# Altium schematic sheet PIN(15~16).SchDoc(PIN(15~16))
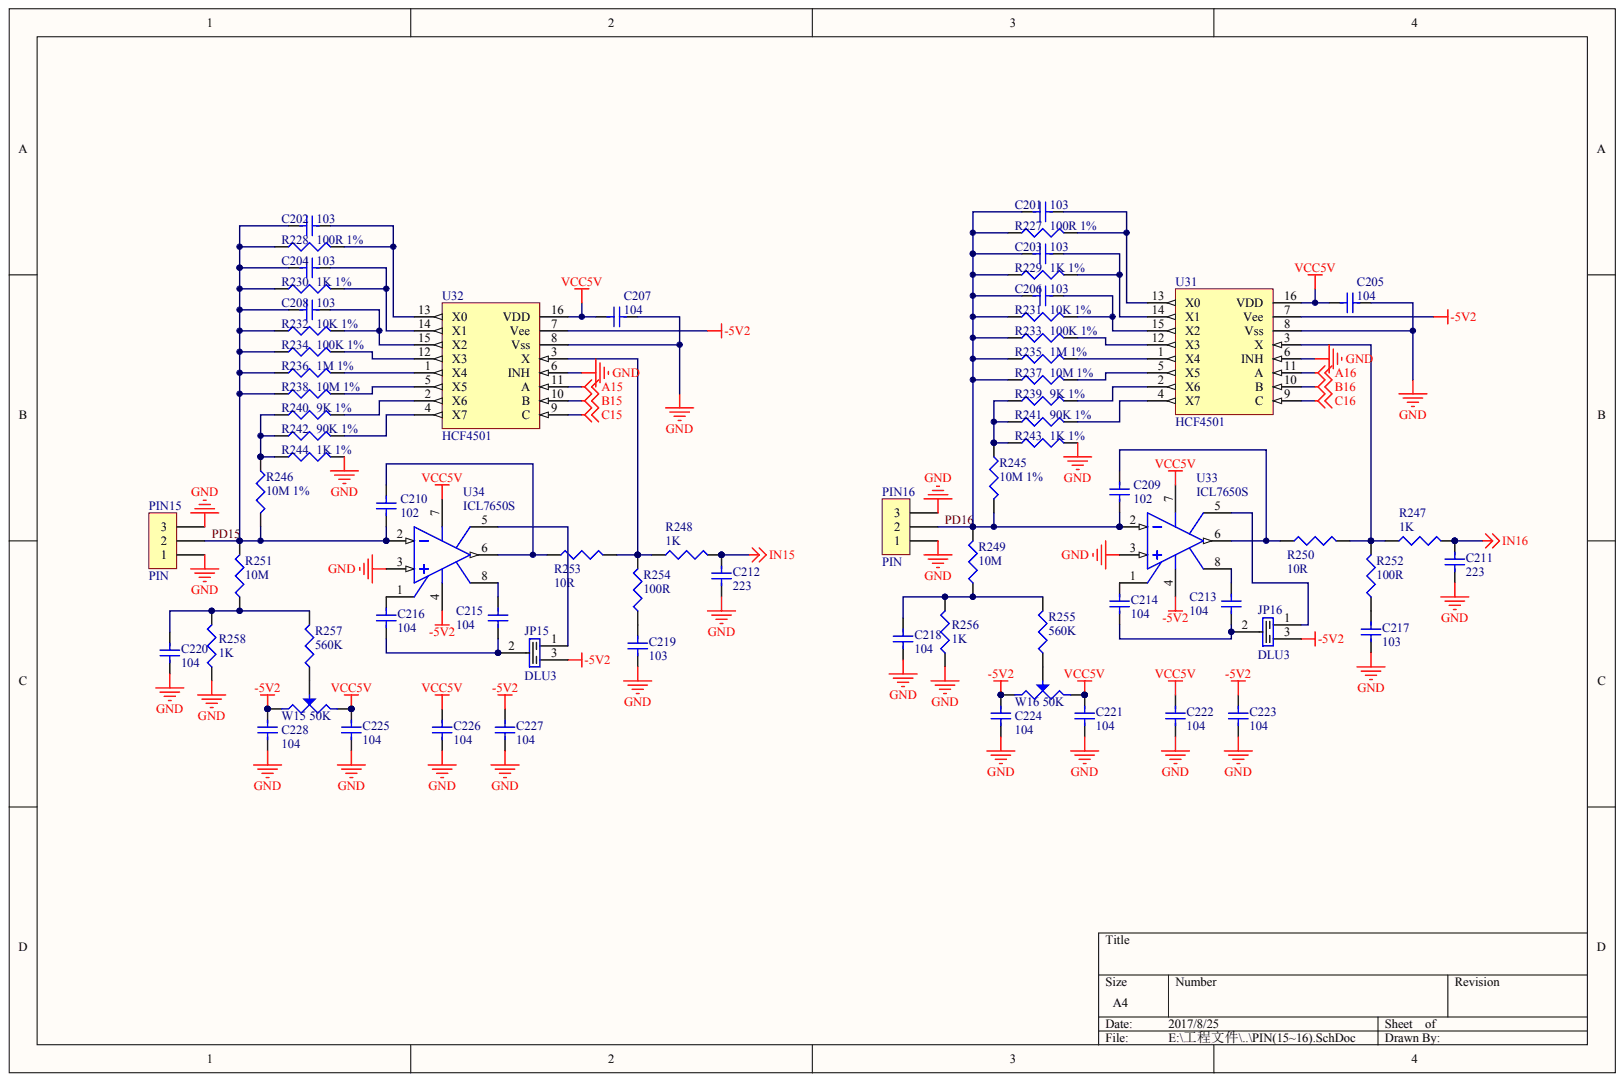
[diagram p0: PIN]

## Parts
C201  103
C202  103
C203  103
C204  103
C205  104
C206  103
C207  104
C208  103
C209  102
C210  102
C211  223
C212  223
C213  104
C214  104
C215  104
C216  104
C217  103
C218  104
C219  103
C220  104
C221  104
C222  104
C223  104
C224  104
C225  104
C226  104
C227  104
C228  104
JP15  DLU3
JP16  DLU3
PIN15  PIN
PIN16  PIN
R227  100R 1%
R228  100R 1%
R229  1K 1%
R230  1K 1%
R231  10K 1%
R232  10K 1%
R233  100K 1%
R234  100K 1%
R235  1M 1%
R236  1M 1%
R237  10M 1%
R238  10M 1%
R239  9K 1%
R240  9K 1%
R241  90K 1%
R242  90K 1%
R243  1K 1%
R244  1K 1%
R245  10M 1%
R246  10M 1%
R247  1K
R248  1K
R249  10M
R250  10R
R251  10M
R252  100R
R253  10R
R254  100R
R255  560K
R256  1K
R257  560K
R258  1K
U31  HCF4501
U32  HCF4501
U33  ICL7650S
U34  ICL7650S
W15  50K
W16  50K

## Nets
-5V2: C223.2 C224.1 C227.2 C228.1 JP15.3 JP16.3 U31.7(Vee) U32.7(Vee) U33.4 U34.4 W15.1 W16.1
A15: U32.11(A)
A16: U31.11(A)
B15: U32.10(B)
B16: U31.10(B)
C15: U32.9(C)
C16: U31.9(C)
GND: C205.2 C207.2 C211.1 C212.1 C217.1 C218.1 C219.1 C220.1 C221.1 C222.1 C223.1 C224.2 C225.1 C226.1 C227.1 C228.2 PIN15.1 PIN15.3 PIN16.1 PIN16.3 R243.2 R244.2 R256.1 R258.1 U31.6(INH) U31.8(Vss) U32.6(INH) U32.8(Vss) U33.3 U34.3
IN15: C212.2 R248.2
IN16: C211.2 R247.2
VCC5V: C205.1 C207.1 C221.2 C222.2 C225.2 C226.2 U31.16(VDD) U32.16(VDD) U33.7 U34.7 W15.3 W16.3
PD15: C202.1 C204.1 C208.1 C210.1 PIN15.2 R228.1 R230.1 R232.1 R234.1 R236.1 R238.1 R246.2 R251.2 U34.2
PD16: C201.1 C203.1 C206.1 C209.1 PIN16.2 R227.1 R229.1 R231.1 R233.1 R235.1 R237.1 R245.2 R249.2 U33.2
NetC201_2: C201.2 R227.2 U31.13(X0)
NetC202_2: C202.2 R228.2 U32.13(X0)
NetC203_2: C203.2 R229.2 U31.14(X1)
NetC204_2: C204.2 R230.2 U32.14(X1)
NetC206_2: C206.2 R231.2 U31.15(X2)
NetC208_2: C208.2 R232.2 U32.15(X2)
NetC209_2: C209.2 R250.1 U33.6
NetC210_2: C210.2 R253.1 U34.6
NetC213_1: C213.1 U33.8
NetC213_2: C213.2 C214.1 JP16.2
NetC214_2: C214.2 U33.1
NetC215_1: C215.1 U34.8
NetC215_2: C215.2 C216.1 JP15.2
NetC216_2: C216.2 U34.1
NetC217_2: C217.2 R252.1
NetC218_2: C218.2 R249.1 R255.2 R256.2
NetC219_2: C219.2 R254.1
NetC220_2: C220.2 R251.1 R257.2 R258.2
NetJP15_1: JP15.1 U34.5
NetJP16_1: JP16.1 U33.5
NetR233_2: R233.2 U31.12(X3)
NetR234_2: R234.2 U32.12(X3)
NetR235_2: R235.2 U31.1(X4)
NetR236_2: R236.2 U32.1(X4)
NetR237_2: R237.2 U31.5(X5)
NetR238_2: R238.2 U32.5(X5)
NetR239_1: R239.1 R241.1 R243.1 R245.1
NetR239_2: R239.2 U31.2(X6)
NetR240_1: R240.1 R242.1 R244.1 R246.1
NetR240_2: R240.2 U32.2(X6)
NetR241_2: R241.2 U31.4(X7)
NetR242_2: R242.2 U32.4(X7)
NetR247_1: R247.1 R250.2 R252.2 U31.3(X)
NetR248_1: R248.1 R253.2 R254.2 U32.3(X)
NetR255_1: R255.1 W16.2
NetR257_1: R257.1 W15.2
